# Altium schematic sheet Kelly Layer v1.2.SchDoc("Kelly Layer v1.2")
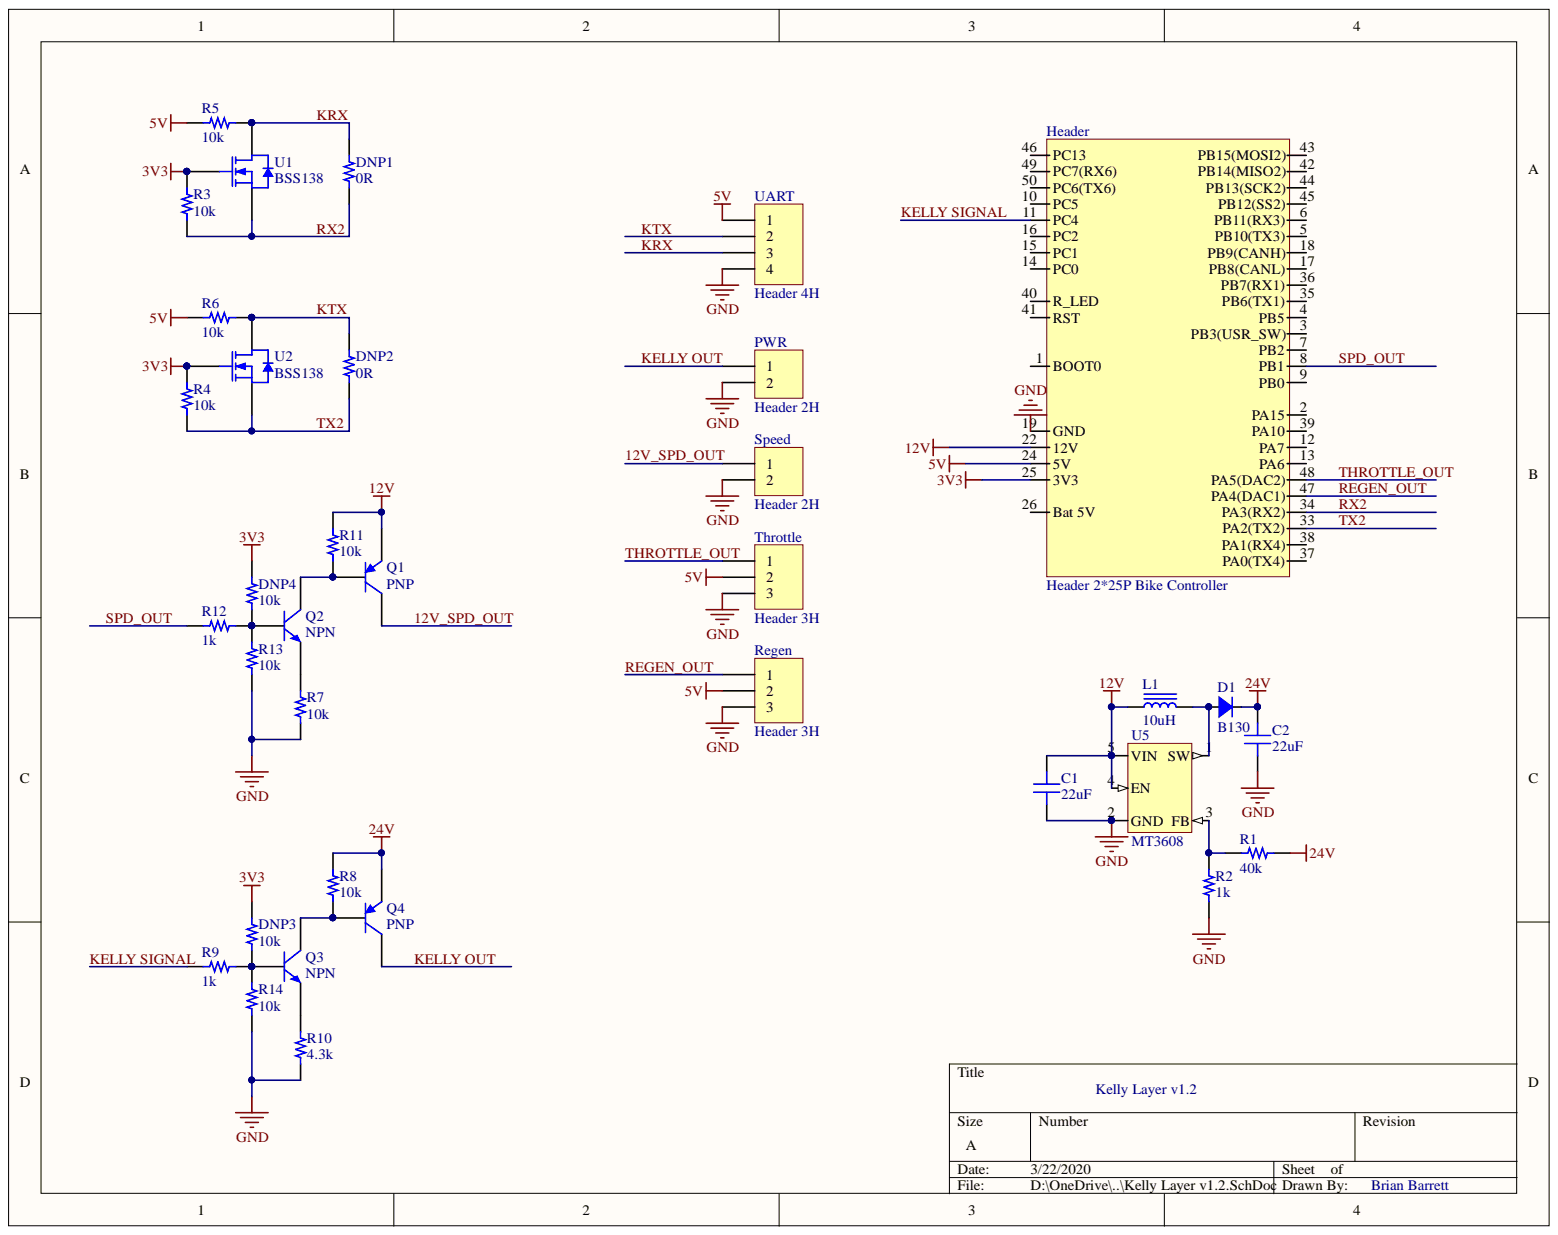
[diagram p0: Kelly Layer v1.2.SchDoc]

## Parts
C1  22uF  [CAP 0805/2012 RF]
C2  22uF  [CAP 0805/2012 RF]
D1  B130  [SOD-123]
DNP1  0R  [CAP 0603/1608 RF]
DNP2  0R  [CAP 0603/1608 RF]
DNP3  10k  [CAP 0603/1608 RF]
DNP4  10k  [CAP 0603/1608 RF]
Header  Header 2*25P Bike Controller  [1.0mm 2X25 Header - Dbl Layer]
L1  10uH  [IND 4x4 2]
PWR  Header 2H  [SH 1.0 * 2]
Q1  PNP  [SOT-23]
Q2  NPN  [SOT-23]
Q3  NPN  [SOT-23]
Q4  PNP  [SOT-23]
R1  40k  [CAP 0603/1608 RF]
R2  1k  [CAP 0603/1608 RF]
R3  10k  [CAP 0603/1608 RF]
R4  10k  [CAP 0603/1608 RF]
R5  10k  [CAP 0603/1608 RF]
R6  10k  [CAP 0603/1608 RF]
R7  10k  [CAP 0603/1608 RF]
R8  10k  [CAP 0603/1608 RF]
R9  1k  [CAP 0603/1608 RF]
R10  4.3k  [CAP 0603/1608 RF]
R11  10k  [CAP 0603/1608 RF]
R12  1k  [CAP 0603/1608 RF]
R13  10k  [CAP 0603/1608 RF]
R14  10k  [CAP 0603/1608 RF]
Regen  Header 3H  [SH 1.0 * 3]
Speed  Header 2H  [SH 1.0 * 2]
Throttle  Header 3H  [SH 1.0 * 3]
U1  BSS138  [SOT-23]
U2  BSS138  [SOT-23]
U5  MT3608  [SOT-23 (6)]
UART  Header 4H  [SH 1.0 * 4]

## Nets
3V3: DNP3.2 DNP4.2 Header.25(3V3) R3.2 R4.2 U1.1 U2.1
5V: Header.24(5V) R5.2 R6.2 Regen.2 Throttle.2 UART.1
12V: C1.1 Header.22(12V) L1.1 Q1.3 R11.1 U5.4(EN) U5.5(VIN)
24V: C2.2 D1.2 Q4.3 R1.2 R8.2
GND: C1.2 C2.1 Header.19(GND) PWR.2 R2.1 R7.2 R10.1 R13.1 R14.1 Regen.3 Speed.2 Throttle.3 U5.2(GND) UART.4
12V_SPD_OUT: Q1.1 Speed.1
KELLY OUT: PWR.1 Q4.1
KELLY SIGNAL: Header.11(PC4) R9.1
KRX: DNP1.1 R5.1 U1.4 UART.3
KTX: DNP2.1 R6.1 U2.4 UART.2
REGEN_OUT: Header.47(PA4(DAC1)) Regen.1
RX2: DNP1.2 Header.34(PA3(RX2)) R3.1 U1.3
SPD_OUT: Header.8(PB1) R12.2
THROTTLE_OUT: Header.48(PA5(DAC2)) Throttle.1
TX2: DNP2.2 Header.33(PA2(TX2)) R4.1 U2.3
NetD1_1: D1.1 L1.2 U5.1(SW)
NetDNP3_1: DNP3.1 Q3.2 R9.2 R14.2
NetDNP4_1: DNP4.1 Q2.2 R12.1 R13.2
NetQ1_2: Q1.2 Q2.3 R11.2
NetQ2_1: Q2.1 R7.1
NetQ3_1: Q3.1 R10.2
NetQ3_3: Q3.3 Q4.2 R8.1
NetR1_1: R1.1 R2.2 U5.3(FB)

Not connected: Header 1(BOOT0) 2(PA15) 3(PB3(USR_SW)) 4(PB5) 5(PB10(TX3)) 6(PB11(RX3)) 7(PB2) 9(PB0) 10(PC5) 12(PA7) 13(PA6) 14(PC0) 15(PC1) 16(PC2) 17(PB8(CANL)) 18(PB9(CANH)) 26(Bat_5V) 35(PB6(TX1)) 36(PB7(RX1)) 37(PA0(TX4)) 38(PA1(RX4)) 39(PA10) 40(R_LED) 41(RST) 42(PB14(MISO2)) 43(PB15(MOSI2)) 44(PB13(SCK2)) 45(PB12(SS2)) 46(PC13) 49(PC7(RX6)) 50(PC6(TX6))

## Library data of the parts
C1 C2 = Capacitor: SMT Capacitor; Component Kind: Standard; Library Name: Passives.SchLib; Value: 22uF
D1 = Diode: Component Kind: Standard; Library Name: BMI.SchLib
DNP1-DNP4 R1-R14 = Resistor: SMT Resistor; Component Kind: Standard; Library Name: Passives.SchLib
  DNP1 DNP2: Value: 0R
  DNP3 DNP4 R3-R8 R11 R13 R14: Value: 10k
  R1: Value: 40k
  R2 R9 R12: Value: 1k
  R10: Value: 4.3k
Header = Header 2*25P Bike Controller: Header; Component Kind: Standard; LatestRevisionDate: 17-Jul-2002; LatestRevisionNote: Re-released for DXP Platform.; Library Name: BMI.SchLib; Publisher: Altium Limited
L1 = Inductor: SMT Inductor; Component Kind: Standard; Library Name: Passives.SchLib; Value: 10uH
PWR Speed = Header 2H: Header, 2-Pin; Component Kind: Standard; LatestRevisionDate: 17-Jul-2002; LatestRevisionNote: Re-released for DXP Platform.; Library Name: BMI.SchLib; Signal Integrity: Connector; Publisher: Altium Limited
Q1 Q4 = PNP: PNP Bipolar Transistor; Component Kind: Standard; LatestRevisionDate: 29-May-2009; LatestRevisionNote: IPC-7351 Footprint Added.; Library Name: BMI.SchLib; PackageDescription: 3-Pin SOT-23 Package 0.95 mm Pitch, 2.4 mm Lead Span; PackageReference: SOT-23B; PackageVersion: Aug-1999; Published: 8-Jun-2000; Signal Integrity: PNP; Simulation: PNP; Publisher: Altium Limited
Q2 Q3 = NPN: PNP Bipolar Transistor; Component Kind: Standard; LatestRevisionDate: 29-May-2009; LatestRevisionNote: IPC-7351 Footprint Added.; Library Name: BMI.SchLib; PackageDescription: 3-Pin SOT-23 Package 0.95 mm Pitch, 2.4 mm Lead Span; PackageReference: SOT-23B; PackageVersion: Aug-1999; Published: 8-Jun-2000; Signal Integrity: PNP; Simulation: PNP; Publisher: Altium Limited
Regen Throttle = Header 3H: Header, 3-Pin; Component Kind: Standard; LatestRevisionDate: 17-Jul-2002; LatestRevisionNote: Re-released for DXP Platform.; Library Name: BMI.SchLib; Signal Integrity: Connector; Publisher: Altium Limited
U1 U2 = N MOSFET: N-Channel MOSFET; Component Kind: Standard; Library Name: BMI.SchLib
UART = Header 4H: Header, 4-Pin; Component Kind: Standard; LatestRevisionDate: 17-Jul-2002; LatestRevisionNote: Re-released for DXP Platform.; Library Name: BMI.SchLib; Signal Integrity: Connector; Publisher: Altium Limited
U5 = MT3608: Component Kind: Standard; Library Name: BMI.SchLib
